# Altium schematic sheet main.SchDoc(main)
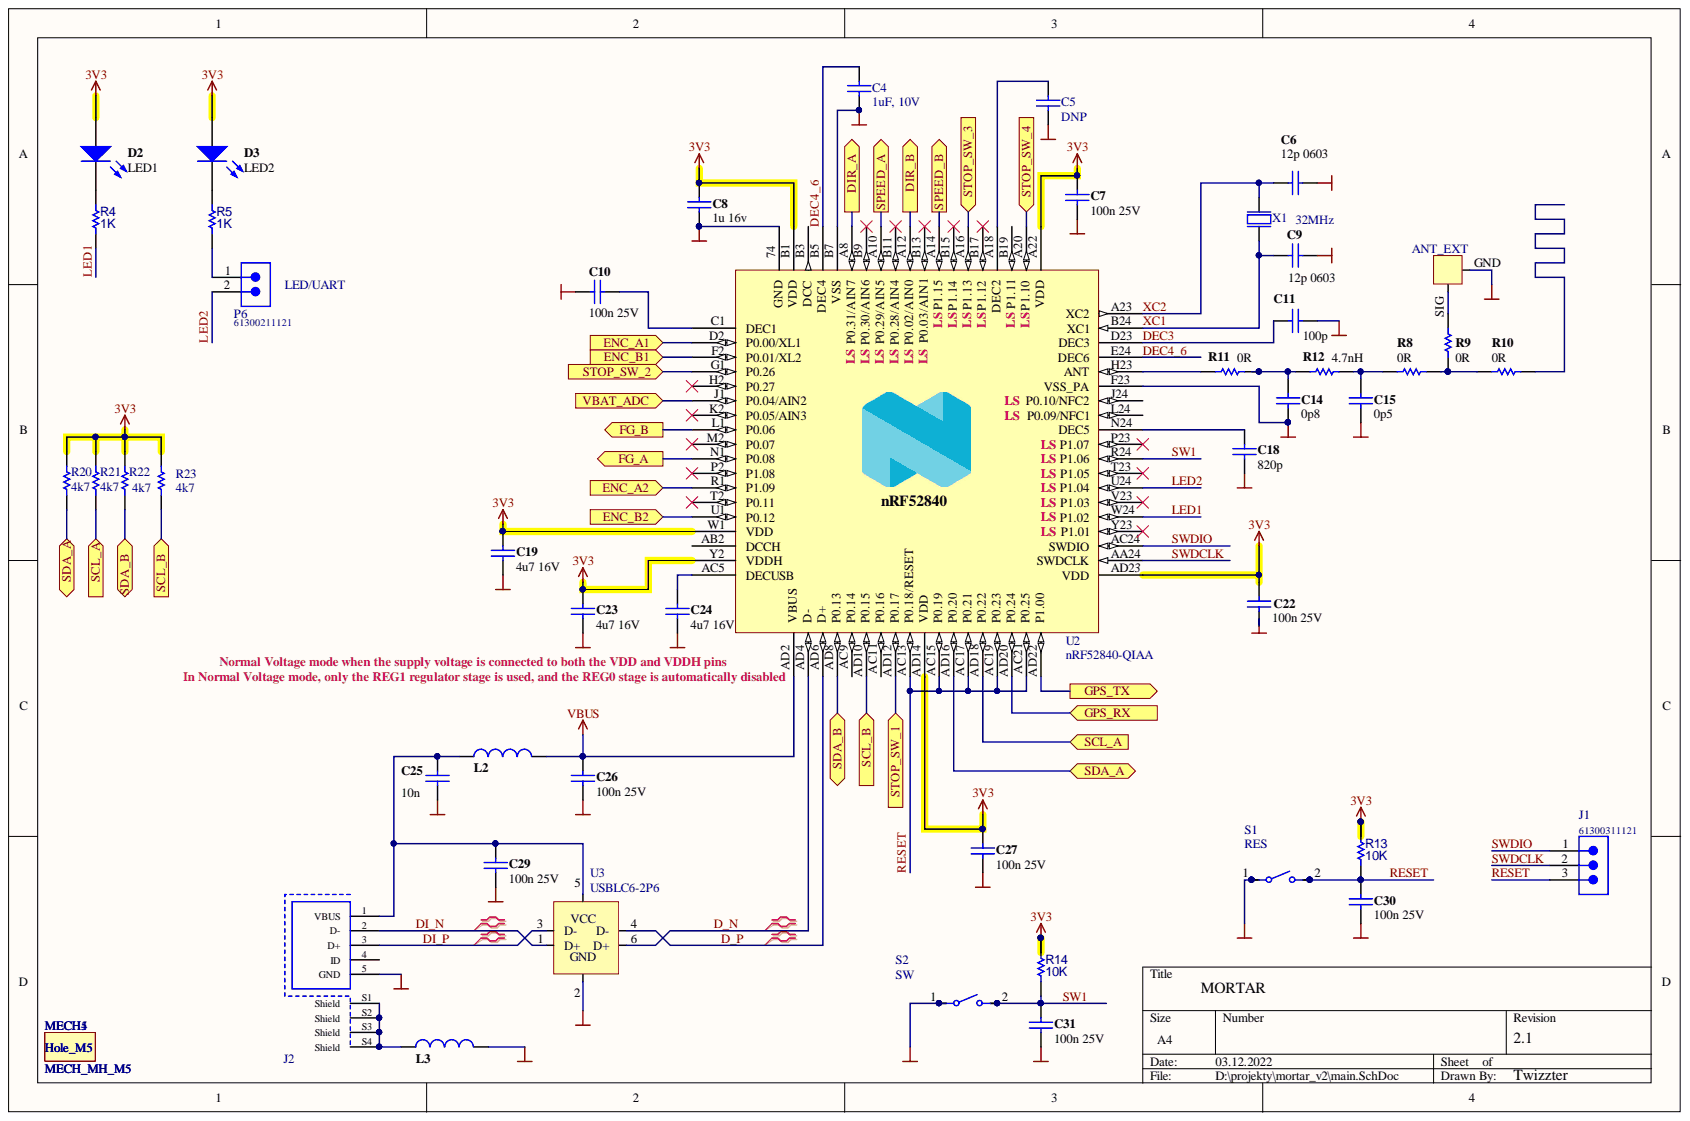
[diagram p0: main.SchDoc]

## Parts
C4  1uF, 10V  [WCAP-CSGP_0603_H=0.8mm_Reflow]
C5  DNP  [WCAP-CSGP_0603_H=0.8mm_Reflow]
C6  12p  value 12p 0603  [C_0603]
C7  value 100n 25V  [C_0603]  (hidden comment: C_100n_25V_0603)
C8  value 1u 16v  [C_0603]  (hidden comment: C_1u_16V)
C9  12p  value 12p 0603  [C_0603]
C10  value 100n 25V  [C_0603]  (hidden comment: C_100n_25V_0603)
C11  100p  [C_0603]
C14  0p8  [WCAP-CSGP_0402_H=0.5mm]
C15  0p5  [WCAP-CSGP_0402_H=0.5mm]
C18  820p  [WCAP-CSGP_0402_H=0.5mm]
C19  value 4u7 16V  [C_0603]  (hidden comment: C_4u7_16V_0603)
C22  value 100n 25V  [C_0603]  (hidden comment: C_100n_25V_0603)
C23  value 4u7 16V  [C_0603]  (hidden comment: C_4u7_16V_0603)
C24  value 4u7 16V  [C_0603]  (hidden comment: C_4u7_16V_0603)
C25  value 10n  [C_0603]  (hidden comment: C_10n_50v_0603)  (net tie)
C26  value 100n 25V  [C_0603]  (hidden comment: C_100n_25V_0603)
C27  value 100n 25V  [C_0603]  (hidden comment: C_100n_25V_0603)
C29  value 100n 25V  [C_0603]  (hidden comment: C_100n_25V_0603)
C30  value 100n 25V  [C_0603]  (hidden comment: C_100n_25V_0603)
C31  value 100n 25V  [C_0603]  (hidden comment: C_100n_25V_0603)
CON2  ANT_EXT  [uFL SMT]
D2  LED1  [D_0805]
D3  LED2  [D_0805]
J1  61300311121  [61300311121]
J2  Micro USB 2.0 Type B Receptacle  [629105150521]  (value not drawn)
L2  FB_0805  [R_0805]  (value not drawn)
L3  FB_0805  [R_0805]  (value not drawn)
MECH1  MECH_MH_M5  [MECH_MH_M5]  (no BOM)
MECH4  MECH_MH_M5  [MECH_MH_M5]  (no BOM)
MECH5  MECH_MH_M5  [MECH_MH_M5]  (no BOM)
P6  61300211121  [61300211121]
R4  VALUE_TXT: 1K  [R_0603]  (hidden comment: R_1K_0603)
R5  VALUE_TXT: 1K  [R_0603]  (hidden comment: R_1K_0603)
R8  0R  [R_0402]
R9  0R  [R_0402]
R10  0R  [R_0402]
R11  0R  [R_0402]
R12  value 4.7nH  [R_0402]  (hidden comment: 4n7)
R13  VALUE_TXT: 10K  [R_0603]  (hidden comment: R_10K_0603)
R14  VALUE_TXT: 10K  [R_0603]  (hidden comment: R_10K_0603)
R20  4k7  [R_0603]
R21  4k7  [R_0603]
R22  4k7  [R_0603]
R23  4k7  [R_0603]
S1  RES  [430152043826]
S2  SW  [430152043826]
U2  nRF52840-QIAA  [AQFN50P700X700X85_HS-74N]
U3  USBLC6-2P6  [SOT666]
X1  32MHz  [BT-XTAL_2016]

## Ports
DIR_A, DIR_B, ENC_A1, ENC_A2, ENC_B1, ENC_B2, FG_A, FG_B, GPS_RX, GPS_TX, SCL_A, SCL_B, SDA_A, SDA_B, SPEED_A, SPEED_B, STOP_SW_1, STOP_SW_2, STOP_SW_3, STOP_SW_4, VBAT_ADC

## Nets
3V3: C7.2 C8.1 C19.2 C22.2 C23.2 C27.2 D2.1 D3.1 R13.2 R14.2 R20.2 R21.2 R22.2 R23.2 U2.A22(VDD) U2.AD14(VDD) U2.AD23(VDD) U2.B1(VDD) U2.W1(VDD) U2.Y2(VDDH)
GND: C4.1 C5.1 C6.1 C7.1 C8.2 C9.1 C10.1 C11.1 C14.1 C15.1 C18.1 C19.1 C22.1 C23.1 C24.1 C25.1 C26.1 C27.1 C29.1 C30.1 C31.1 CON2.GND J2.5(GND) L3.1 S1.1 S1.3 S2.1 S2.3 U2.74(GND) U2.B7(VSS) U2.F23(VSS_PA) U3.2 X1.2 X1.4
VBUS: C26.2 L2.1 U2.AD2(VBUS)
D_N: U2.AD4(D-) U3.6(D+)
D_P: U2.AD6(D+) U3.4(D-)
DEC3: C11.2 U2.D23(DEC3)
DEC4_6: C4.2 U2.B5(DEC4) U2.E24(DEC6)
DI_N: J2.2(D-) U3.1(D+)
DI_P: J2.3(D+) U3.3(D-)
DIR_A: U2.A8(LS_P0.31/AIN7) ; port DIR_A
DIR_B: U2.A12(LS_P0.02/AIN0) ; port DIR_B
ENC_A1: U2.D2(P0.00/XL1) ; port ENC_A1
ENC_A2: U2.R1(P1.09) ; port ENC_A2
ENC_B1: U2.F2(P0.01/XL2) ; port ENC_B1
ENC_B2: U2.U1(P0.12) ; port ENC_B2
FG_A: U2.N1(P0.08) ; port FG_A
FG_B: U2.L1(P0.06) ; port FG_B
GPS_RX: U2.AD20(P0.24) ; port GPS_RX
GPS_TX: U2.AD22(P1.00) ; port GPS_TX
LED1: R4.1 U2.W24(LS_P1.02)
LED2: P6.2 U2.U24(LS_P1.04)
RESET: C30.2 J1.3 R13.1 S1.2 S1.4 U2.AC13(P0.18/RESET) U2.AC15(P0.19) U2.AC17(P0.21) U2.AC19(P0.23) U2.AC21(P0.25)
SCL_A: R21.1 U2.AD18(P0.22) ; port SCL_A
SCL_B: R23.1 U2.AD10(P0.15) ; port SCL_B
SDA_A: R20.1 U2.AD16(P0.20) ; port SDA_A
SDA_B: R22.1 U2.AD8(P0.13) ; port SDA_B
SPEED_A: U2.A10(LS_P0.29/AIN5) ; port SPEED_A
SPEED_B: U2.A14(LS_P1.15) ; port SPEED_B
STOP_SW_1: U2.AD12(P0.17) ; port STOP_SW_1
STOP_SW_2: U2.G1(P0.26) ; port STOP_SW_2
STOP_SW_3: U2.A16(LS_P1.13) ; port STOP_SW_3
STOP_SW_4: U2.A20(LS_P1.10) ; port STOP_SW_4
SW1: C31.2 R14.1 S2.2 S2.4 U2.R24(LS_P1.06)
SWDCLK: J1.2 U2.AA24(SWDCLK)
SWDIO: J1.1 U2.AC24(SWDIO)
VBAT_ADC: U2.J1(P0.04/AIN2) ; port VBAT_ADC
XC1: C9.2 U2.B24(XC1) X1.1
XC2: C6.2 U2.A23(XC2) X1.3
NetC5_2: C5.2 U2.A18(DEC2)
NetC10_2: C10.2 U2.C1(DEC1)
NetC14_2: C14.2 R11.2 R12.1
NetC15_2: C15.2 R8.1 R12.2
NetC18_2: C18.2 U2.N24(DEC5)
NetC24_2: C24.2 U2.AC5(DECUSB)
NetC25_2: C25.2 C29.2 J2.1(VBUS) L2.2 U3.5
NetCON2_SIG: CON2.SIG R9.2
NetD2_2: D2.2 R4.2
NetD3_2: D3.2 R5.2
NetJ2_S1: J2.S1(Shield) J2.S2(Shield) J2.S3(Shield) J2.S4(Shield) L3.2
NetP6_1: P6.1 R5.1
NetR8_2: R8.2 R9.1 R10.1
NetR11_1: R11.1 U2.H23(ANT)

Not connected: J2 4(ID); R10 2; U2 AB2(DCCH) AC9(P0.14) AC11(P0.16) B3(DCC) B9(LS_P0.30/AIN6) B11(LS_P0.28/AIN4) B13(P0.03/AIN1) B15(LS_P1.14) B17(LS_P1.12) B19(LS_P1.11) H2(P0.27) J24(P0.10/NFC2) K2(P0.05/AIN3) L24(P0.09/NFC1) M2(P0.07) P2(P1.08) P23(LS_P1.07) T2(P0.11) T23(LS_P1.05) V23(LS_P1.03) Y23(LS_P1.01)

## Library data of the parts
C4 C5 = C_1u_10V_0603: WCAP-CSGP Multilayer Ceramic Chip Capacitor, General Purpose, size 0603, X7R, 1uF, 10V; Manufacturer Part Number: 885012206026; Manufacturer: Wurth Elektronik; Technical Reference: X7R0603105K010DFCT10000; Rated Voltage: 10VDC; Isolation Resistance  min.: 0.1GOhm; Dissipation Factor max.: 10%; Capacitance Tolerance: +/-10%; Size: 0603; Q-Factor min.: -; Published Date: April 2021; Packaging: Tape and Reel; Operating Temperature: -55 to +125degC; Mounting Technology: SMT; Library Name: SCH.SchLib; Height: 0.8mm; ComponentLink2URL: https://www.we-online.com/catalog/datasheet/885012206026.pdf; ComponentLink2Description: Datasheet; ComponentLink1URL: http://www.we-online.de; ComponentLink1Description: Manufacturer; Component Kind: Standard; Ceramic Type: X7R; Capacitance: 1uF
C6 C9 C11 = C_0603: C; Library Name: SCH.SchLib; Component Kind: Standard
C7 C10 C22 C26 C27 C29-C31 = C_100n_25V_0603: 100n 25V 0603 X7R; mfg_pn: 885012206071; Library Name: SCH.SchLib; Component Kind: Standard
  C7: Value: 100n 25V
C8 = C_1u_16V: Value: 1u 16v; mfg_pn: EMK107B7105KA-T; Library Name: SCH.SchLib; Component Kind: Standard
C14 C15 C18 = C_0402: C; Library Name: SCH.SchLib; Component Kind: Standard
  C14: Value: 0p8
  C15: Value: 0p5
  C18: Value: 820p
C19 C23 = C_4u7_16V_0603: 4u7 16V 0603 X6S; mfg_pn: C1608X6S1C475K080AC; Library Name: SCH.SchLib; Component Kind: Standard
C24 = C_4u7_16V_0603: 4u7 16V 0603 X6S; Value: 4u7 16V; mfg_pn: C1608X6S1C475K080AC; Library Name: SCH.SchLib; Component Kind: Standard
C25 = C_10n_50v_0603: 10n 50V 0603; mfg_pn: CL10B103KB8NNNC; Rated Voltage: 50v; Library Name: SCH.SchLib
CON2 = UFL: Library Name: SCH.SchLib; Component Kind: Standard
D2 D3 = LED_0805: LED; mfg_pn: LL-S170VC-V1-1B; Library Name: SCH.SchLib; Component Kind: Standard
J1 = 61300311121: WR-PHD Pin Header, THT, pitch 2.54mm, Single Row, Vertical, 3pin; Working Voltage: 250VAC; Withstanding Voltage: 500VAC; Rated Current: 3A; Pin Number: 3; Gender: Male; Contact Resistance: 20mOhm; Row Number: Single; Published Date: June 2019; Pitch: 2.54mm; Packaging: Bag; Orientation: Vertical; Operating Temperature: -40 to +105degC; Mounting Technology: THT; mfg_pn: 61300311121; Library Name: SCH.SchLib; ComponentLink2URL: https://katalog.we-online.de/em/datasheet/6130xx11121.pdf; ComponentLink2Description: Datasheet; ComponentLink1URL: http://www.we-online.de; ComponentLink1Description: Manufacturer; Component Kind: Standard; Publisher: Wurth Elektronik
J2 = 629105150521: Micro USB 2.0 Type B, Receptacle, Horizontal, SMT, with Pegs; Working Voltage: 30VAC; Rated Current: 3A; Pin Number: 5; Interface Type: Type B; Type: Horizontal; Published Date: September 2019; Packaging: Tape and Reel; Operating Temperature: -40 to +85degC; Mounting Technology: SMT; mfg_pn: 629105150521; Gender: Receptacle; ComponentLink2URL: https://katalog.we-online.com/em/datasheet/629105150521.pdf; ComponentLink2Description: Datasheet; ComponentLink1URL: http://www.we-online.de; ComponentLink1Description: Manufacturer; Component Kind: Standard; Application: Micro USB 2.0; Publisher: Wurth Elektronik; Library Name: Kayon_01.SCHLIB
L2 L3 = L_0603: SMD-Multilayer Inductor; PackageVersion: 2009; PackageReference: SMD-0603; Library Name: SCH.SchLib; Component Kind: Standard
P6 = 61300211121: WR-PHD Pin Header, THT, pitch 2.54mm, Single Row, Vertical, 2p; Manufacturer: Wurth Elektronik; Working Voltage: 250VAC; Withstanding Voltage: 500VAC; Rated Current: 3A; Pin Number: 2; Contact Resistance: 20mOhm; Row Number: Single; Published Date: May 2020; Pitch: 2.54mm; Packaging: Bag; Orientation: Vertical; Operating Temperature: -40 to +105degC; Mounting Technology: THT; Manufacturer Part Number: 61300211121; Library Name: SCH.SchLib; Gender: Pin Header; ComponentLink2URL: https://www.we-online.com/catalog/datasheet/61300211121.pdf; ComponentLink2Description: Datasheet; ComponentLink1URL: http://www.we-online.de; ComponentLink1Description: Manufacturer; Component Kind: Standard
R4 R5 = R_1K_0603: SMD RES 1K-1%-1/10W; Manufacturer: YAGEO; Package: 0603; Library Name: SCH.SchLib; Component Kind: Standard
R8-R12 = R_0402: 0402 (1005 Metric)  SMD; Tolerance: 5 %; Power: 0.063 W; Case-Metric: 1005; Case-EIA: 0402; Technology: SMT; Library Name: SCH.SchLib; Component Kind: Standard
  R8-R11: Value: 0R
R13 R14 = R_10K_0603: SMD RES 10K-5%-1/10W; Manufacturer: YAGEO; Package: 0603; Library Name: SCH.SchLib; Component Kind: Standard
R20-R23 = R_0603: Library Name: SCH.SchLib; Component Kind: Standard
S1 S2 = 430152043826: WS-TASV SMT Tact Switch 6x6mm, height 4.3mm, 260gf; Washable: No; Rated Voltage: 12VDC; Rated Current: 50mA; Terminal Plating: Silver; Terminal Material: Copper Alloy; Published Date: September 2019; Packaging: Tape and Reel; Operation Force: 260gf; Operating Temperature: -40 to +85degC; Mounting Technology: SMT; mfg_pn: 430152043826; Library Name: SCH.SchLib; Height: 4.3mm; Contact Plating: Silver; Contact Material: Stainless Steel; ComponentLink2URL: https://katalog.we-online.com/em/datasheet/430152043826.pdf; ComponentLink2Description: Datasheet; ComponentLink1URL: http://www.we-online.de; ComponentLink1Description: Manufacturer; Component Kind: Standard; Publisher: Wurth Elektronik
U2 = nRF52840-QIAAD0: Multi-protocol Bluetooth Low Energy, IEEE 802.15.4, ANT and 2.4GHz proprietary system-on-chip; Part number IPS: N.A.; Manufacturer: Nordic Semiconductor; Database Table Name: nRF Devices$; Value: nRF52840-QIAA; Supplier Part Number 1: nRF52840-QIAAD0; Supplier 1: Nordic Semiconductor; Published: 2018-12-04; Pin Count: 73; Part Number: nRF52840-QIAAD0; Manufacturer Part Number: nRF52840-QIAAD0; Library Name: Nordic Active DB.DbLib; LatestRevisionDate: 2018-12-04; Footprint Doc: AQFN-73; ComponentLink2Description: Supplier link; ComponentLink1Description: Datasheet; Component Kind: Standard; Artikkelnr Noca: N.A.; Publisher: Nordic Semiconductor
U3 = USBLC6-2SC6: mfg_pn: USBLC6-2P6; Component Kind: Standard; Library Name: Kayon_01.SCHLIB
X1 = XTAL_SMD2016_32MHz_8pF_General: XTAL SMD 2016, 32MHz, Cl=8pF, Total Tol: ±40ppm; Pad Count: 4; Value: 32MHz; Published: 2016-08-19; Part Number: XTAL_SMD2016_32MHz_8pF_General; Library Name: SCH.SchLib; LatestRevisionDate: 2016-08-19; Footprint Doc: XTAL_2016; Component Kind: Standard
MECH1 MECH4 MECH5 = MECH_MH_M5: Library Name: SCH.SchLib
